# Altium schematic sheet BREEZE Pro Main V1.SchDoc
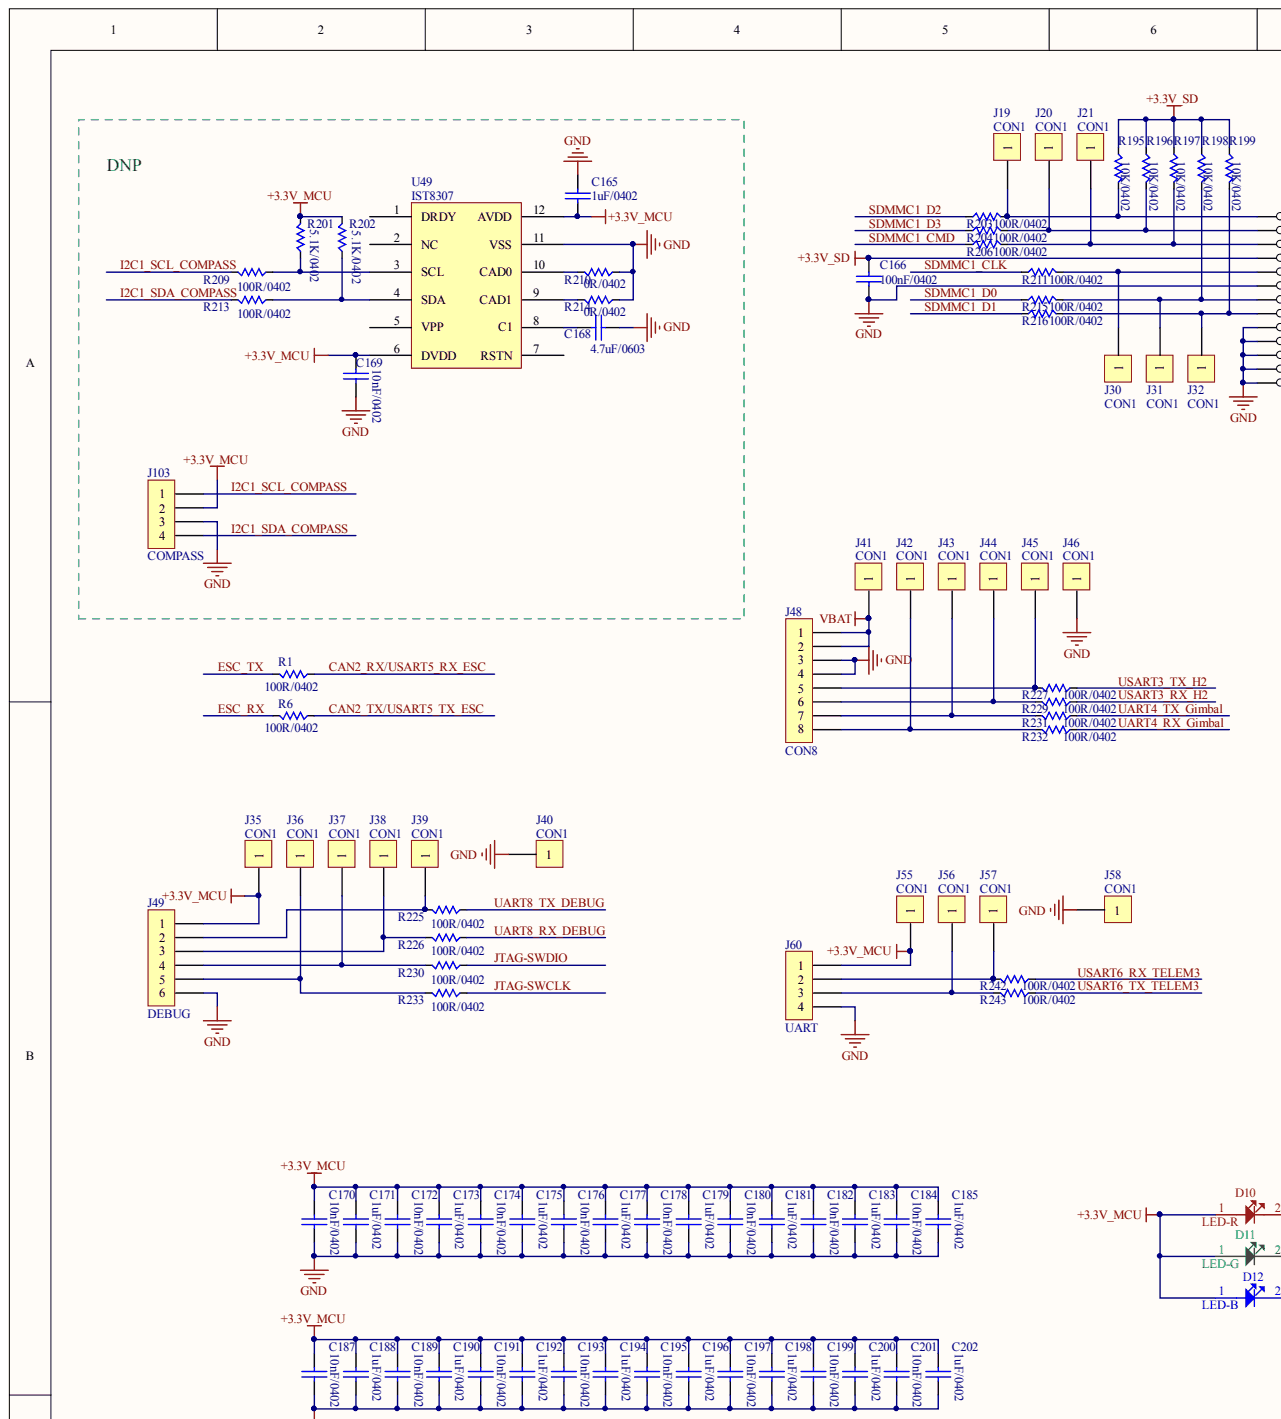
[diagram p0: BREEZE Pro Main V1.SchDoc, top left]
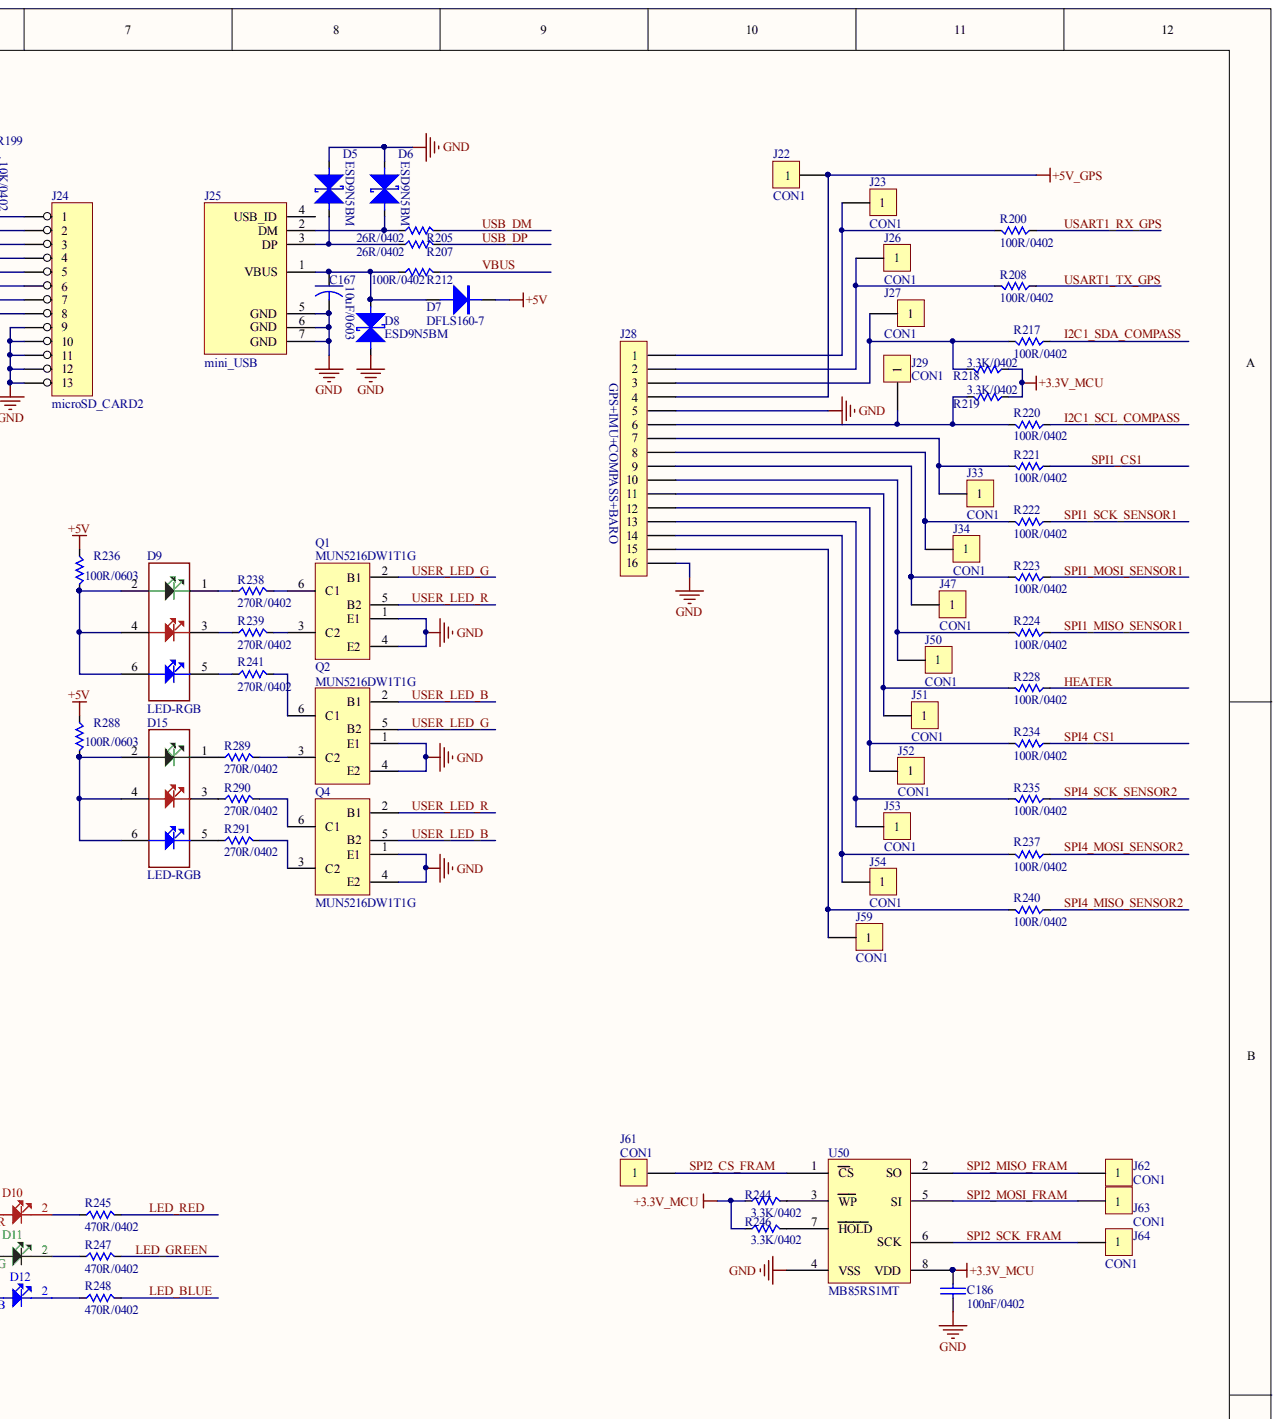
[diagram p1: BREEZE Pro Main V1.SchDoc, top right]
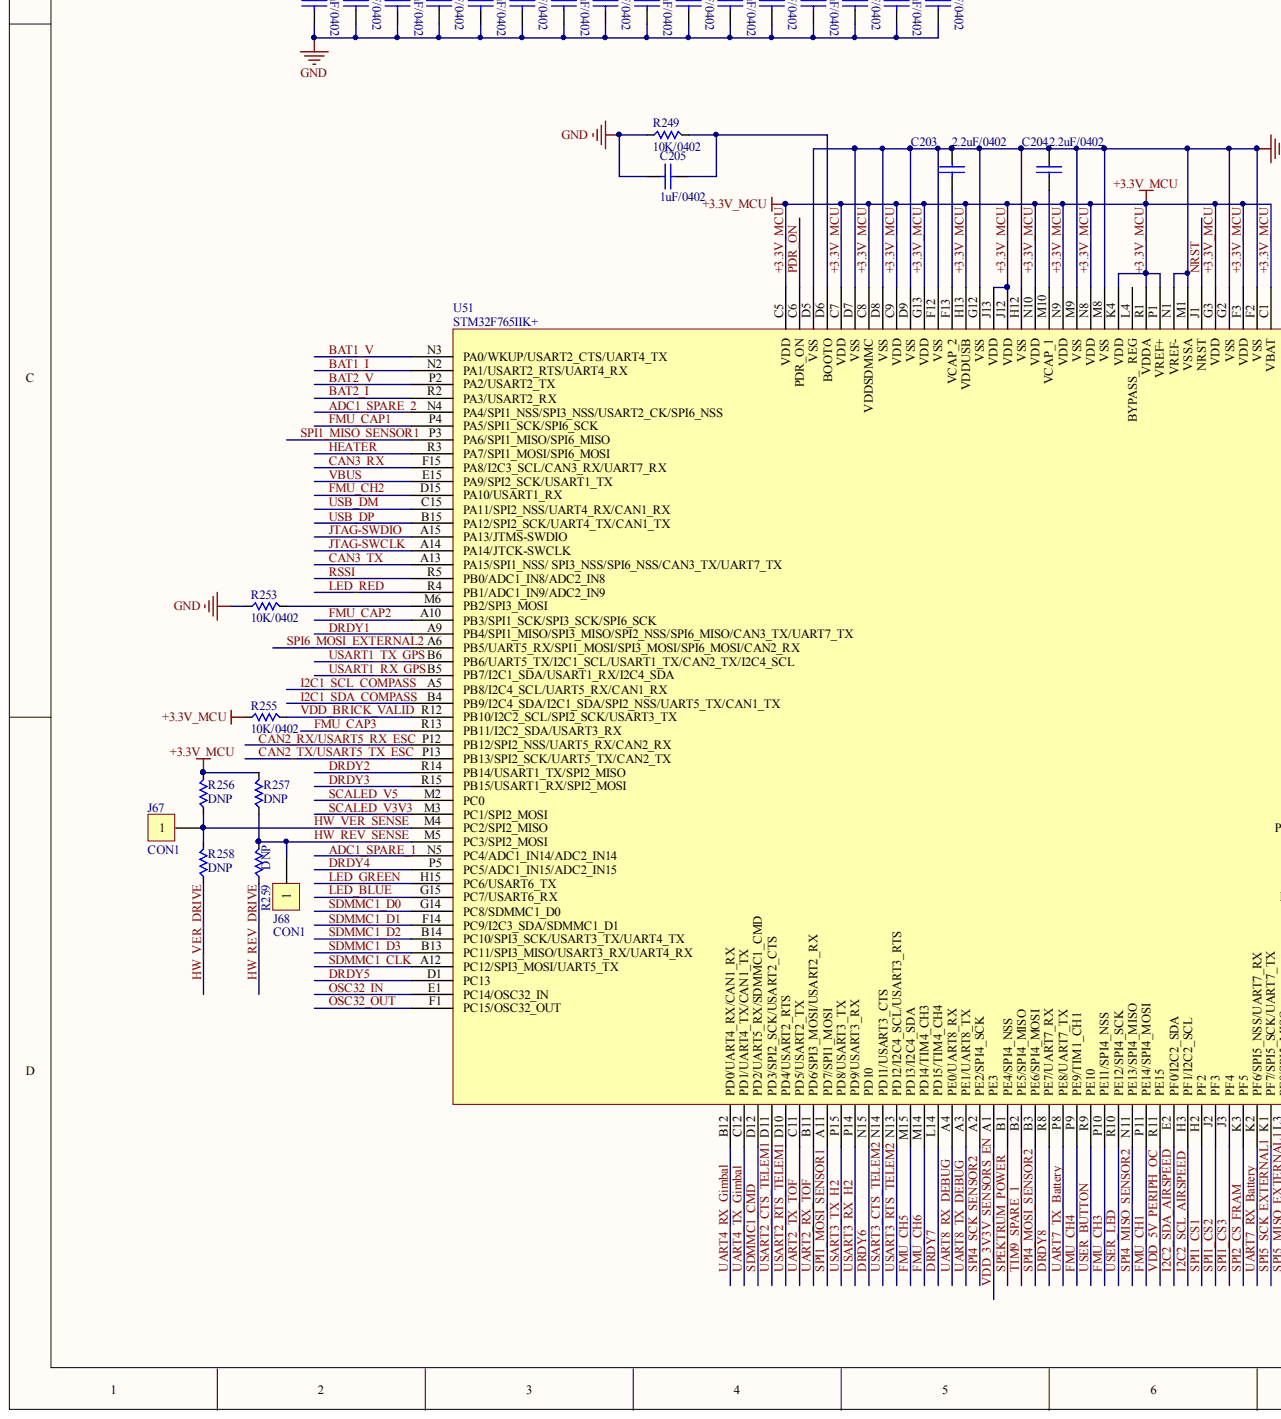
[diagram p2: BREEZE Pro Main V1.SchDoc, bottom left]
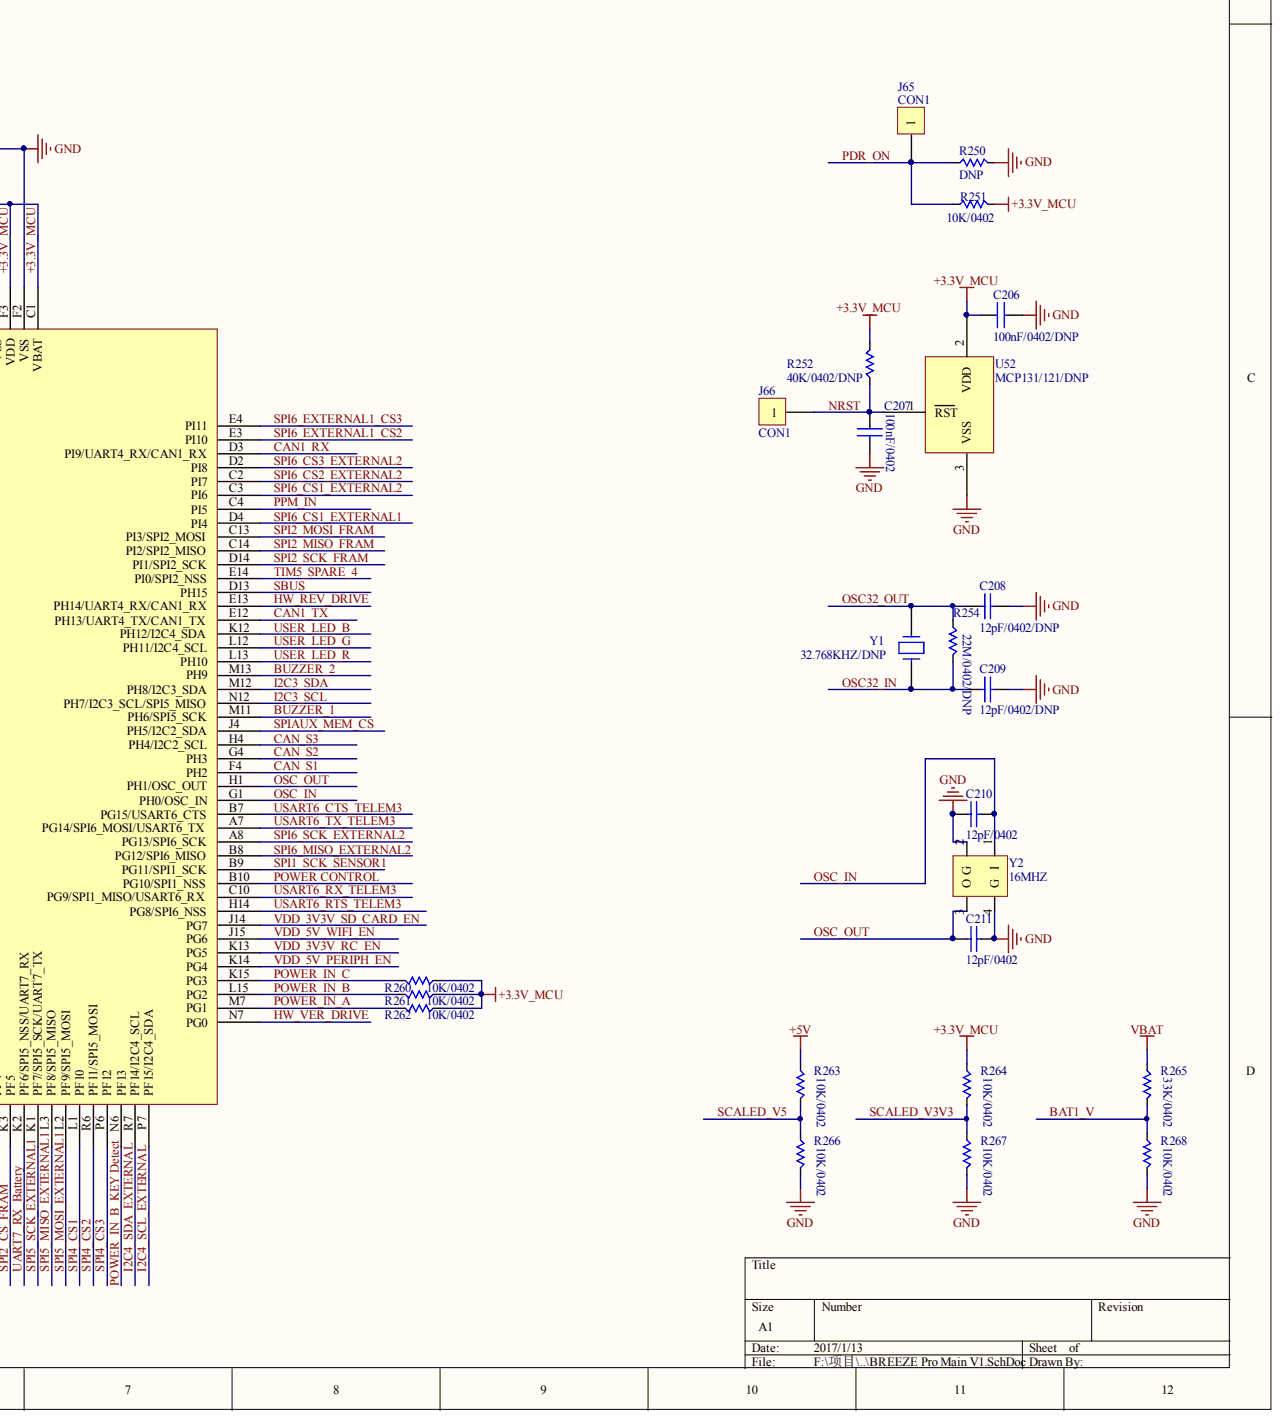
[diagram p3: BREEZE Pro Main V1.SchDoc, bottom right]

## Parts
C165  1uF/0402
C166  100nF/0402
C167  10uF/0603
C168  4.7uF/0603
C169  10nF/0402
C170  10nF/0402
C171  1uF/0402
C172  10nF/0402
C173  1uF/0402
C174  10nF/0402
C175  1uF/0402
C176  10nF/0402
C177  1uF/0402
C178  10nF/0402
C179  1uF/0402
C180  10nF/0402
C181  1uF/0402
C182  10nF/0402
C183  1uF/0402
C184  10nF/0402
C185  1uF/0402
C186  100nF/0402
C187  10nF/0402
C188  1uF/0402
C189  10nF/0402
C190  1uF/0402
C191  10nF/0402
C192  1uF/0402
C193  10nF/0402
C194  1uF/0402
C195  10nF/0402
C196  1uF/0402
C197  10nF/0402
C198  1uF/0402
C199  10nF/0402
C200  1uF/0402
C201  10nF/0402
C202  1uF/0402
C203  2.2uF/0402
C204  2.2uF/0402
C205  1uF/0402
C206  100nF/0402/DNP
C207  100nF/0402
C208  12pF/0402/DNP
C209  12pF/0402/DNP
C210  12pF/0402
C211  12pF/0402
D5  ESD9N5BM
D6  ESD9N5BM
D7  DFLS160-7
D8  ESD9N5BM
D9  LED-RGB
D10  LED-R
D11  LED-G
D12  LED-B
D15  LED-RGB
J19  CON1
J20  CON1
J21  CON1
J22  CON1
J23  CON1
J24  microSD_CARD2
J25  mini_USB
J26  CON1
J27  CON1
J28  GPS+IMU+COMPASS+BARO
J29  CON1
J30  CON1
J31  CON1
J32  CON1
J33  CON1
J34  CON1
J35  CON1
J36  CON1
J37  CON1
J38  CON1
J39  CON1
J40  CON1
J41  CON1
J42  CON1
J43  CON1
J44  CON1
J45  CON1
J46  CON1
J47  CON1
J48  CON8
J49  DEBUG
J50  CON1
J51  CON1
J52  CON1
J53  CON1
J54  CON1
J55  CON1
J56  CON1
J57  CON1
J58  CON1
J59  CON1
J60  UART
J61  CON1
J62  CON1
J63  CON1
J64  CON1
J65  CON1
J66  CON1
J67  CON1
J68  CON1
J103  COMPASS
Q1  MUN5216DW1T1G
Q2  MUN5216DW1T1G
Q4  MUN5216DW1T1G
R1  100R/0402
R6  100R/0402
R195  10K/0402
R196  10K/0402
R197  10K/0402
R198  10K/0402
R199  10K/0402
R200  100R/0402
R201  5.1K/0402
R202  5.1K/0402
R203  100R/0402
R204  100R/0402
R205  26R/0402
R206  100R/0402
R207  26R/0402
R208  100R/0402
R209  100R/0402
R210  0R/0402
R211  100R/0402
R212  100R/0402
R213  100R/0402
R214  0R/0402
R215  100R/0402
R216  100R/0402
R217  100R/0402
R218  3.3K/0402
R219  3.3K/0402
R220  100R/0402
R221  100R/0402
R222  100R/0402
R223  100R/0402
R224  100R/0402
R225  100R/0402
R226  100R/0402
R227  100R/0402
R228  100R/0402
R229  100R/0402
R230  100R/0402
R231  100R/0402
R232  100R/0402
R233  100R/0402
R234  100R/0402
R235  100R/0402
R236  100R/0603
R237  100R/0402
R238  270R/0402
R239  270R/0402
R240  100R/0402
R241  270R/0402
R242  100R/0402
R243  100R/0402
R244  3.3K/0402
R245  470R/0402
R246  3.3K/0402
R247  470R/0402
R248  470R/0402
R249  10K/0402
R250  DNP
R251  10K/0402
R252  40K/0402/DNP
R253  10K/0402
R254  22M/0402/DNP
R255  10K/0402
R256  DNP
R257  DNP
R258  DNP
R259  DNP
R260  10K/0402
R261  10K/0402
R262  10K/0402
R263  10K/0402
R264  10K/0402
R265  33K/0402
R266  10K/0402
R267  10K/0402
R268  10K/0402
R288  100R/0603
R289  270R/0402
R290  270R/0402
R291  270R/0402
U49  IST8307
U50  MB85RS1MT
U51  STM32F765IIK+
U52  MCP131/121/DNP
Y1  32.768KHZ/DNP
Y2  16MHZ

## Nets
+3.3V_SD: C166.1 J24.4 R195.1 R196.1 R197.1 R198.1 R199.1
+5V: D7.2 R236.1 R263.1 R288.1
+5V_GPS: J22.1 J28.4
GND: C165.1 C166.2 C167.2 C168.1 C169.1 C170.1 C171.1 C172.1 C173.1 C174.1 C175.1 C176.1 C177.1 C178.1 C179.1 C180.1 C181.1 C182.1 C183.1 C184.1 C185.1 C186.2 C187.1 C188.1 C189.1 C190.1 C191.1 C192.1 C193.1 C194.1 C195.1 C196.1 C197.1 C198.1 C199.1 C200.1 C201.1 C202.1 C203.2 C204.1 C205.2 C206.2 C207.2 C208.1 C209.1 C210.2 C211.1 D5.1 D6.1 D8.1 J24.6 J24.9 J24.10 J24.11 J24.12 J24.13 J25.5(GND) J25.6(GND) J25.7(GND) J28.5 J28.16 J40.1 J46.1 J48.3 J48.4 J49.6 J58.1 J60.4 J103.3 Q1.1(E1) Q1.4(E2) Q2.1(E1) Q2.4(E2) Q4.1(E1) Q4.4(E2) R210.1 R214.1 R249.1 R250.2 R253.1 R266.2 R267.2 R268.2 U49.11(VSS) U50.4(VSS) U51.D5(VSS) U51.D7(VSS) U51.D8(VSS) U51.D9(VSS) U51.F2(VSS) U51.F12(VSS) U51.G2(VSS) U51.G12(VSS) U51.H12(VSS) U51.M1(VSSA) U51.M8(VSS) U51.M9(VSS) U51.N1(VREF-) U52.3 Y2.2(G) Y2.4(G)
VBAT: J41.1 J48.1 J48.2 R265.1
+3.3V_MCU: C165.2 C169.2 C170.2 C171.2 C172.2 C173.2 C174.2 C175.2 C176.2 C177.2 C178.2 C179.2 C180.2 C181.2 C182.2 C183.2 C184.2 C185.2 C186.1 C187.2 C188.2 C189.2 C190.2 C191.2 C192.2 C193.2 C194.2 C195.2 C196.2 C197.2 C198.2 C199.2 C200.2 C201.2 C202.2 C206.1 D10.1 D11.1 D12.1 J35.1 J49.1 J55.1 J60.1 J103.2 R201.2 R202.2 R218.1 R219.1 R244.1 R246.1 R251.2 R252.2 R255.1 R256.2 R257.2 R260.1 R261.1 R262.1 R264.1 U49.6(DVDD) U49.12(AVDD) U50.8(VDD) U51.C1(VBAT) U51.C5(VDD) U51.C7(VDD) U51.C8(VDDSDMMC) U51.C9(VDD) U51.F3(VDD) U51.G3(VDD) U51.G13(VDD) U51.H13(VDDUSB) U51.J12(VDD) U51.J13(VDD) U51.K4(VDD) U51.N8(VDD) U51.N9(VDD) U51.N10(VDD) U51.P1(VREF+) U51.R1(VDDA) U52.2
ADC1_SPARE_1: U51.N5(PC4/ADC1_IN14/ADC2_IN14)
ADC1_SPARE_2: U51.N4(PA4/SPI1_NSS/SPI3_NSS/USART2_CK/SPI6_NSS)
BAT1_I: U51.N2(PA1/USART2_RTS/UART4_RX)
BAT1_V: R265.2 R268.1 U51.N3(PA0/WKUP/USART2_CTS/UART4_TX)
BAT2_I: U51.R2(PA3/USART2_RX)
BAT2_V: U51.P2(PA2/USART2_TX)
BUZZER_1: U51.M11(PH6/SPI5_SCK)
BUZZER_2: U51.M13(PH9)
CAN1_RX: U51.D3(PI9/UART4_RX/CAN1_RX)
CAN1_TX: U51.E12(PH13/UART4_TX/CAN1_TX)
CAN2_RX/USART5_RX_ESC: R1.1 U51.P12(PB12/SPI2_NSS/UART5_RX/CAN2_RX)
CAN2_TX/USART5_TX_ESC: R6.1 U51.P13(PB13/SPI2_SCK/UART5_TX/CAN2_TX)
CAN3_RX: U51.F15(PA8/I2C3_SCL/CAN3_RX/UART7_RX)
CAN3_TX: U51.A13(PA15/SPI1_NSS/_SPI3_NSS/SPI6_NSS/CAN3_TX/UART7_TX)
CAN_S1: U51.F4(PH2)
CAN_S2: U51.G4(PH3)
CAN_S3: U51.H4(PH4/I2C2_SCL)
DRDY1: U51.A9(PB4/SPI1_MISO/SPI3_MISO/SPI2_NSS/SPI6_MISO/CAN3_TX/UART7_TX)
DRDY2: U51.R14(PB14/USART1_TX/SPI2_MISO)
DRDY3: U51.R15(PB15/USART1_RX/SPI2_MOSI)
DRDY4: U51.P5(PC5/ADC1_IN15/ADC2_IN15)
DRDY5: U51.D1(PC13)
DRDY6: U51.N15(PD10)
DRDY7: U51.L14(PD15/TIM4_CH4)
DRDY8: U51.R8(PE7/UART7_RX)
ESC_RX: R6.2
ESC_TX: R1.2
FMU_CAP1: U51.P4(PA5/SPI1_SCK/SPI6_SCK)
FMU_CAP2: U51.A10(PB3/SPI1_SCK/SPI3_SCK/SPI6_SCK)
FMU_CAP3: U51.R13(PB11/I2C2_SDA/USART3_RX)
FMU_CH1: U51.P11(PE14/SPI4_MOSI)
FMU_CH2: U51.D15(PA10/USART1_RX)
FMU_CH3: U51.P10(PE11/SPI4_NSS)
FMU_CH4: U51.P9(PE9/TIM1_CH1)
FMU_CH5: U51.M15(PD13/I2C4_SDA)
FMU_CH6: U51.M14(PD14/TIM4_CH3)
HEATER: R228.1 U51.R3(PA7/SPI1_MOSI/SPI6_MOSI)
HW_REV_DRIVE: R259.1 U51.E13(PH14/UART4_RX/CAN1_RX)
HW_REV_SENSE: J68.1 R257.1 R259.2 U51.M5(PC3/SPI2_MOSI)
HW_VER_DRIVE: R258.1 U51.N7(PG0)
HW_VER_SENSE: J67.1 R256.1 R258.2 U51.M4(PC2/SPI2_MISO)
I2C1_SCL_COMPASS: J103.1 R209.2 R220.1 U51.A5(PB8/I2C4_SCL/UART5_RX/CAN1_RX)
I2C1_SDA_COMPASS: J103.4 R213.2 R217.1 U51.B4(PB9/I2C4_SDA/I2C1_SDA/SPI2_NSS/UART5_TX/CAN1_TX)
I2C2_SCL_AIRSPEED: U51.H3(PF1/I2C2_SCL)
I2C2_SDA_AIRSPEED: U51.E2(PF0/I2C2_SDA)
I2C3_SCL: U51.N12(PH7/I2C3_SCL/SPI5_MISO)
I2C3_SDA: U51.M12(PH8/I2C3_SDA)
I2C4_SCL_EXTERNAL: U51.P7(PF15/I2C4_SDA)
I2C4_SDA_EXTERNAL: U51.R7(PF14/I2C4_SCL)
JTAG-SWCLK: R233.1 U51.A14(PA14/JTCK-SWCLK)
JTAG-SWDIO: R230.1 U51.A15(PA13/JTMS-SWDIO)
LED_BLUE: R248.2 U51.G15(PC7/USART6_RX)
LED_GREEN: R247.2 U51.H15(PC6/USART6_TX)
LED_RED: R245.2 U51.R4(PB1/ADC1_IN9/ADC2_IN9)
NRST: C207.1 J66.1 R252.1 U51.J1(NRST) U52.1
OSC32_IN: C209.2 R254.1 U51.E1(PC14/OSC32_IN) Y1.2
OSC32_OUT: C208.2 R254.2 U51.F1(PC15/OSC32_OUT) Y1.1
OSC_IN: C210.1 U51.G1(PH0/OSC_IN) Y2.1(I)
OSC_OUT: C211.2 U51.H1(PH1/OSC_OUT) Y2.3(O)
PDR_ON: J65.1 R250.1 R251.1 U51.C6(PDR_ON)
POWER CONTROL: U51.B10(PG10/SPI1_NSS)
POWER_IN_A: R262.2 U51.M7(PG1)
POWER_IN_B: R261.2 U51.L15(PG2)
POWER_IN_B_KEY DETECT: U51.N6(PF13)
POWER_IN_C: R260.2 U51.K15(PG3)
PPM_IN: U51.C4(PI5)
RSSI: U51.R5(PB0/ADC1_IN8/ADC2_IN8)
SBUS: U51.D13(PH15)
SCALED_V3V3: R264.2 R267.1 U51.M3(PC1/SPI2_MOSI)
SCALED_V5: R263.2 R266.1 U51.M2(PC0)
SDMMC1_CLK: R211.1 U51.A12(PC12/SPI3_MOSI/UART5_TX)
SDMMC1_CMD: R206.1 U51.D12(PD2/UART5_RX/SDMMC1_CMD)
SDMMC1_D0: R215.1 U51.G14(PC8/SDMMC1_D0)
SDMMC1_D1: R216.1 U51.F14(PC9/I2C3_SDA/SDMMC1_D1)
SDMMC1_D2: R203.1 U51.B14(PC10/SPI3_SCK/USART3_TX/UART4_TX)
SDMMC1_D3: R204.1 U51.B13(PC11/SPI3_MISO/USART3_RX/UART4_RX)
SPEKTRUM_POWER: U51.B1(PE4/SPI4_NSS)
SPI1_CS1: R221.1 U51.H2(PF2)
SPI1_CS2: U51.J2(PF3)
SPI1_CS3: U51.J3(PF4)
SPI1_MISO_SENSOR1: R224.1 U51.P3(PA6/SPI1_MISO/SPI6_MISO)
SPI1_MOSI_SENSOR1: R223.1 U51.A11(PD7/SPI1_MOSI)
SPI1_SCK_SENSOR1: R222.1 U51.B9(PG11/SPI1_SCK)
SPI2_CS_FRAM: J61.1 U50.1(CS) U51.K3(PF5)
SPI2_MISO_FRAM: J62.1 U50.2(SO) U51.C14(PI2/SPI2_MISO)
SPI2_MOSI_FRAM: J63.1 U50.5(SI) U51.C13(PI3/SPI2_MOSI)
SPI2_SCK_FRAM: J64.1 U50.6(SCK) U51.D14(PI1/SPI2_SCK)
SPI4_CS1: R234.1 U51.L1(PF10)
SPI4_CS2: U51.R6(PF11/SPI5_MOSI)
SPI4_CS3: U51.P6(PF12)
SPI4_MISO_SENSOR2: R240.1 U51.N11(PE13/SPI4_MISO)
SPI4_MOSI_SENSOR2: R237.1 U51.B3(PE6/SPI4_MOSI)
SPI4_SCK_SENSOR2: R235.1 U51.A2(PE2/SPI4_SCK)
SPI5_MISO_EXTERNAL1: U51.L3(PF8/SPI5_MISO)
SPI5_MOSI_EXTERNAL1: U51.L2(PF9/SPI5_MOSI)
SPI5_SCK_EXTERNAL1: U51.K1(PF7/SPI5_SCK/UART7_TX)
SPI6_CS1_EXTERNAL1: U51.D4(PI4)
SPI6_CS1_EXTERNAL2: U51.C3(PI6)
SPI6_CS2_EXTERNAL2: U51.C2(PI7)
SPI6_CS3_EXTERNAL2: U51.D2(PI8)
SPI6_EXTERNAL1_CS2: U51.E3(PI10)
SPI6_EXTERNAL1_CS3: U51.E4(PI11)
SPI6_MISO_EXTERNAL2: U51.B8(PG12/SPI6_MISO)
SPI6_MOSI_EXTERNAL2: U51.A6(PB5/UART5_RX/SPI1_MOSI/SPI3_MOSI/SPI6_MOSI/CAN2_RX)
SPI6_SCK_EXTERNAL2: U51.A8(PG13/SPI6_SCK)
SPIAUX_MEM_CS: U51.J4(PH5/I2C2_SDA)
TIM5_SPARE_4: U51.E14(PI0/SPI2_NSS)
TIM9_SPARE_1: U51.B2(PE5/SPI4_MISO)
UART2_RX_TOF: U51.B11(PD6/SPI3_MOSI/USART2_RX)
UART2_TX_TOF: U51.C11(PD5/USART2_TX)
UART4_RX_GIMBAL: R232.1 U51.B12(PD0/UART4_RX/CAN1_RX)
UART4_TX_GIMBAL: R231.1 U51.C12(PD1/UART4_TX/CAN1_TX)
UART7_RX_BATTERY: U51.K2(PF6/SPI5_NSS/UART7_RX)
UART7_TX_BATTERY: U51.P8(PE8/UART7_TX)
UART8_RX_DEBUG: R226.1 U51.A4(PE0/UART8_RX)
UART8_TX_DEBUG: R225.1 U51.A3(PE1/UART8_TX)
USART1_RX_GPS: R200.1 U51.B5(PB7/I2C1_SDA/USART1_RX/I2C4_SDA)
USART1_TX_GPS: R208.1 U51.B6(PB6/UART5_TX/I2C1_SCL/USART1_TX/CAN2_TX/I2C4_SCL)
USART2_CTS_TELEM1: U51.D11(PD3/SPI2_SCK/USART2_CTS)
USART2_RTS_TELEM1: U51.D10(PD4/USART2_RTS)
USART3_CTS_TELEM2: U51.N14(PD11/USART3_CTS)
USART3_RTS_TELEM2: U51.N13(PD12/I2C4_SCL/USART3_RTS)
USART3_RX_H2: R229.1 U51.P14(PD9/USART3_RX)
USART3_TX_H2: R227.1 U51.P15(PD8/USART3_TX)
USART6_CTS_TELEM3: U51.B7(PG15/USART6_CTS)
USART6_RTS_TELEM3: U51.H14(PG8/SPI6_NSS)
USART6_RX_TELEM3: R242.1 U51.C10(PG9/SPI1_MISO/USART6_RX)
USART6_TX_TELEM3: R243.1 U51.A7(PG14/SPI6_MOSI/USART6_TX)
USB_DM: R205.1 U51.C15(PA11/SPI2_NSS/UART4_RX/CAN1_RX)
USB_DP: R207.1 U51.B15(PA12/SPI2_SCK/UART4_TX/CAN1_TX)
USER_BUTTON: U51.R9(PE10)
USER_LED: U51.R10(PE12/SPI4_SCK)
USER_LED_B: Q2.2(B1) Q4.5(B2) U51.K12(PH12/I2C4_SDA)
USER_LED_G: Q1.2(B1) Q2.5(B2) U51.L12(PH11/I2C4_SCL)
USER_LED_R: Q1.5(B2) Q4.2(B1) U51.L13(PH10)
VBUS: R212.1 U51.E15(PA9/SPI2_SCK/USART1_TX)
VDD_3V3V_RC_EN: U51.K13(PG5)
VDD_3V3V_SD_CARD_EN: U51.J14(PG7)
VDD_3V3V_SENSORS_EN: U51.A1(PE3)
VDD_5V_PERIPH_EN: U51.K14(PG4)
VDD_5V_PERIPH_OC: U51.R11(PE15)
VDD_5V_WIFI_EN: U51.J15(PG6)
VDD_BRICK_VALID: R255.2 U51.R12(PB10/I2C2_SCL/SPI2_SCK/USART3_TX)
NetC167_1: C167.1 D7.1 D8.2 J25.1(VBUS) R212.2
NetC168_2: C168.2 U49.8(C1)
NetC203_1: C203.1 U51.F13(VCAP_2)
NetC204_2: C204.2 U51.M10(VCAP_1)
NetC205_1: C205.1 R249.2 U51.D6(BOOTO)
NetD5_2: D5.2 J25.3(DP) R207.2
NetD6_2: D6.2 J25.2(DM) R205.2
NetD9_1: D9.1 R238.1
NetD9_2: D9.2 D9.4 D9.6 R236.2
NetD9_3: D9.3 R239.1
NetD9_5: D9.5 R241.1
NetD10_2: D10.2 R245.1
NetD11_2: D11.2 R247.1
NetD12_2: D12.2 R248.1
NetD15_1: D15.1 R289.1
NetD15_2: D15.2 D15.4 D15.6 R288.2
NetD15_3: D15.3 R290.1
NetD15_5: D15.5 R291.1
NetJ19_1: J19.1 J24.1 R195.2 R203.2
NetJ20_1: J20.1 J24.2 R196.2 R204.2
NetJ21_1: J21.1 J24.3 R197.2 R206.2
NetJ23_1: J23.1 J28.1 R200.2
NetJ24_5: J24.5 J30.1 R211.2
NetJ24_7: J24.7 J31.1 R198.2 R215.2
NetJ24_8: J24.8 J32.1 R199.2 R216.2
NetJ26_1: J26.1 J28.2 R208.2
NetJ27_1: J27.1 J28.3 R217.2 R218.2
NetJ28_6: J28.6 J29.1 R219.2 R220.2
NetJ28_7: J28.7 J33.1 R221.2
NetJ28_8: J28.8 J34.1 R222.2
NetJ28_9: J28.9 J47.1 R223.2
NetJ28_10: J28.10 J50.1 R224.2
NetJ28_11: J28.11 J51.1 R228.2
NetJ28_12: J28.12 J52.1 R234.2
NetJ28_13: J28.13 J53.1 R235.2
NetJ28_14: J28.14 J54.1 R237.2
NetJ28_15: J28.15 J59.1 R240.2
NetJ36_1: J36.1 J49.5 R233.2
NetJ37_1: J37.1 J49.4 R230.2
NetJ38_1: J38.1 J49.3 R226.2
NetJ39_1: J39.1 J49.2 R225.2
NetJ42_1: J42.1 J48.8 R232.2
NetJ43_1: J43.1 J48.7 R231.2
NetJ44_1: J44.1 J48.6 R229.2
NetJ45_1: J45.1 J48.5 R227.2
NetJ56_1: J56.1 J60.3 R243.2
NetJ57_1: J57.1 J60.2 R242.2
NetQ1_3: Q1.3(C2) R239.2
NetQ1_6: Q1.6(C1) R238.2
NetQ2_3: Q2.3(C2) R289.2
NetQ2_6: Q2.6(C1) R241.2
NetQ4_3: Q4.3(C2) R291.2
NetQ4_6: Q4.6(C1) R290.2
NetR201_1: R201.1 R209.1 U49.3(SCL)
NetR202_1: R202.1 R213.1 U49.4(SDA)
NetR210_2: R210.2 U49.10(CAD0)
NetR214_2: R214.2 U49.9(CAD1)
NetR244_2: R244.2 U50.3(WP)
NetR246_2: R246.2 U50.7(HOLD)
NetR253_2: R253.2 U51.M6(PB2/SPI3_MOSI)

Not connected: J25 4(USB_ID); U49 1(DRDY) 2(NC) 5(VPP) 7(RSTN); U51 L4(REG)

## Library data of the parts
C206 C207 = (no symbol name): Text Field1: 20; Text Field2: 10
